# Altium schematic sheet haptic_module.SchDoc
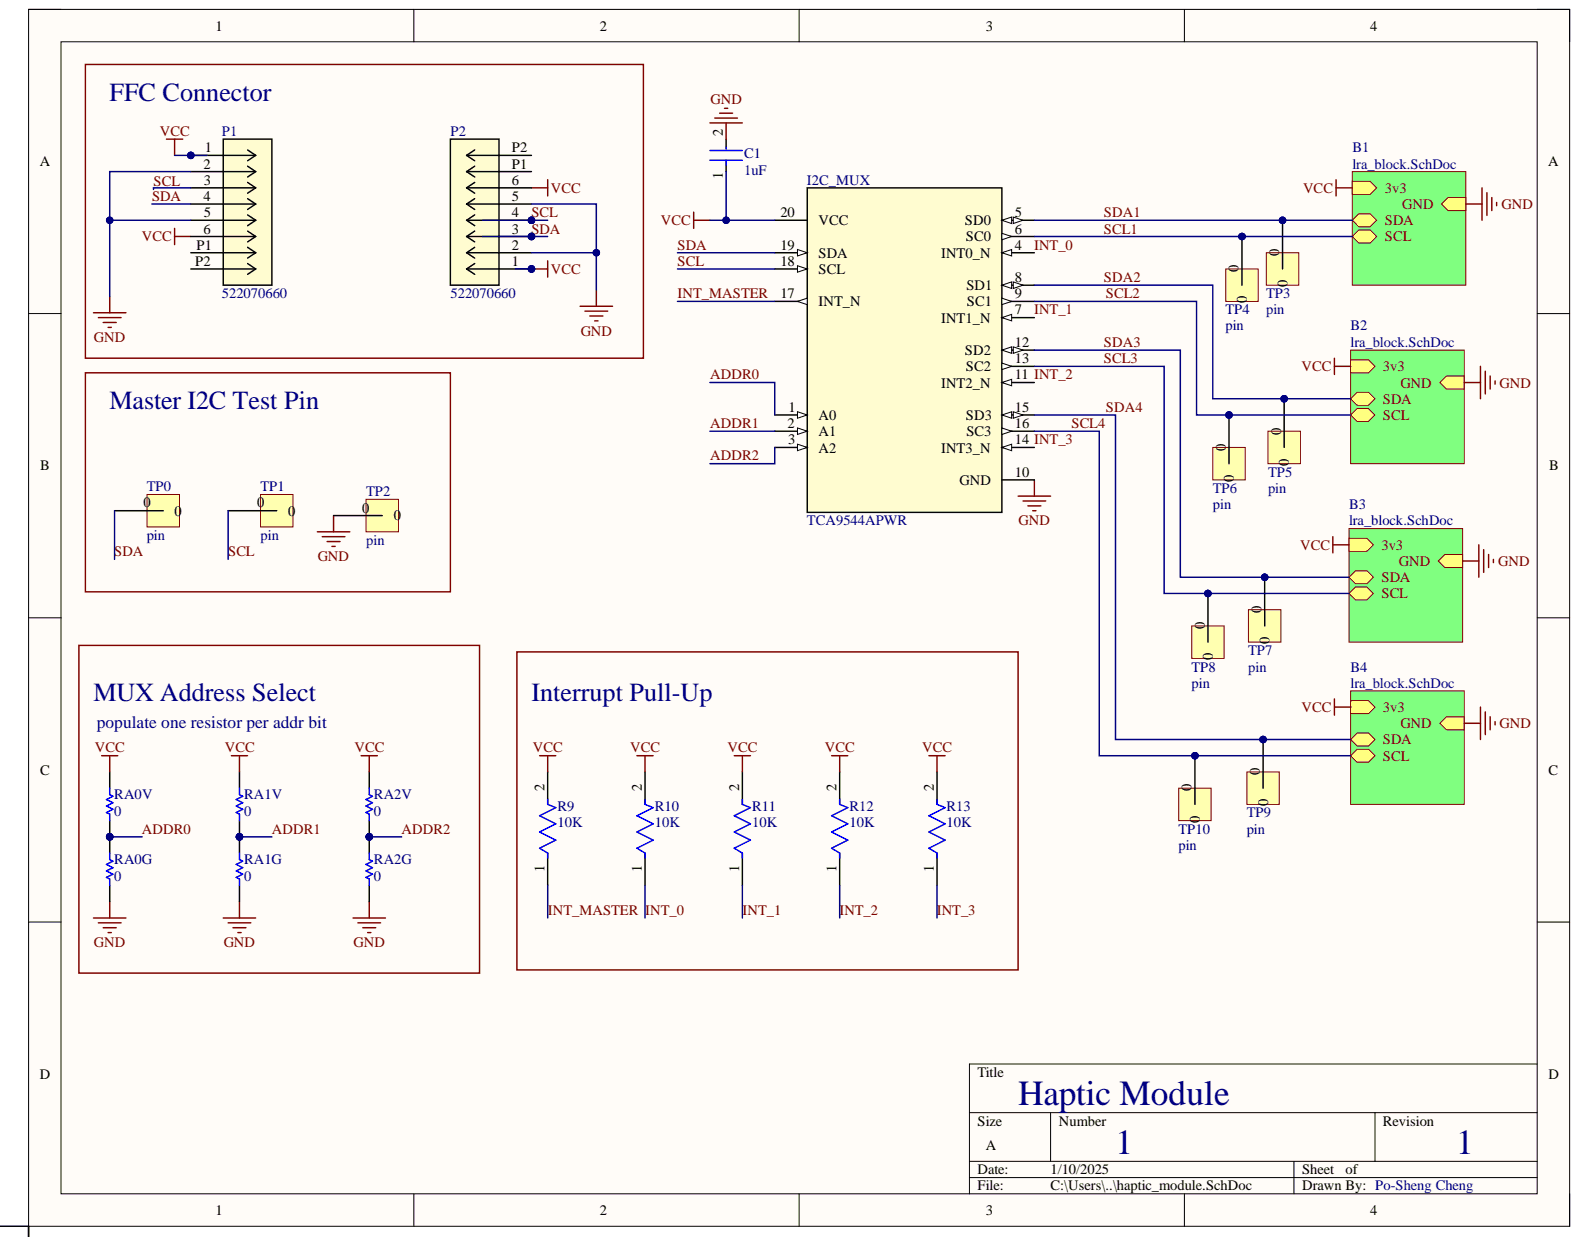
[diagram p0: haptic_module.SchDoc]

## Parts
C1  1uF  [FP-CC0805-0_85-MFG]
I2C_MUX  TCA9544APWR  [PW0020A_L]
P1  522070660  [CON_522070660_MOL]
P2  522070660  [CON_522070660_MOL]
R9  10K  [FP-RT0603-MFG]
R10  10K  [FP-RT0603-MFG]
R11  10K  [FP-RT0603-MFG]
R12  10K  [FP-RT0603-MFG]
R13  10K  [FP-RT0603-MFG]
RA0G  0  [RESC1608X55X25ML10T15]
RA0V  0  [RESC1608X55X25ML10T15]
RA1G  0  [RESC1608X55X25ML10T15]
RA1V  0  [RESC1608X55X25ML10T15]
RA2G  0  [RESC1608X55X25ML10T15]
RA2V  0  [RESC1608X55X25ML10T15]
TP0  pin  [PCBCOMPONENT_1]
TP1  pin  [PCBCOMPONENT_1]
TP2  pin  [PCBCOMPONENT_1]
TP3  pin  [PCBCOMPONENT_1]
TP4  pin  [PCBCOMPONENT_1]
TP5  pin  [PCBCOMPONENT_1]
TP6  pin  [PCBCOMPONENT_1]
TP7  pin  [PCBCOMPONENT_1]
TP8  pin  [PCBCOMPONENT_1]
TP9  pin  [PCBCOMPONENT_1]
TP10  pin  [PCBCOMPONENT_1]

## Nets
GND: C1.2 I2C_MUX.10(GND) P1.2 P1.5 P2.2 P2.5 RA0G.1 RA1G.1 RA2G.1 TP2.0
VCC: C1.1 I2C_MUX.20(VCC) P1.1 P1.6 P2.1 P2.6 R9.2 R10.2 R11.2 R12.2 R13.2 RA0V.2 RA1V.2 RA2V.2
ADDR0: I2C_MUX.1(A0) RA0G.2 RA0V.1
ADDR1: I2C_MUX.2(A1) RA1G.2 RA1V.1
ADDR2: I2C_MUX.3(A2) RA2G.2 RA2V.1
INT_0: I2C_MUX.4(INT0_N) R10.1
INT_1: I2C_MUX.7(INT1_N) R11.1
INT_2: I2C_MUX.11(INT2_N) R12.1
INT_3: I2C_MUX.14(INT3_N) R13.1
INT_MASTER: I2C_MUX.17(INT_N) R9.1
SCL: I2C_MUX.18(SCL) P1.3 P2.4 TP1.0
SCL1: I2C_MUX.6(SC0) TP4.0
SCL2: I2C_MUX.9(SC1) TP6.0
SCL3: I2C_MUX.13(SC2) TP8.0
SCL4: I2C_MUX.16(SC3) TP10.0
SDA: I2C_MUX.19(SDA) P1.4 P2.3 TP0.0
SDA1: I2C_MUX.5(SD0) TP3.0
SDA2: I2C_MUX.8(SD1) TP5.0
SDA3: I2C_MUX.12(SD2) TP7.0
SDA4: I2C_MUX.15(SD3) TP9.0
NetP1_P1: P1.P1
NetP1_P2: P1.P2
NetP2_P1: P2.P1
NetP2_P2: P2.P2

## Library data of the parts
C1 = CMP-03422-000001-1: CAP CER 1UF 16V X7R 0805; Manufacturer: Yageo; Manufacturer Part Number: CC0805KKX7R7BB105; Material: Ceramic; Tolerance: 0.1; Automotive: No; Capacitance: 1 uF; Category: Cap; Component Kind: Standard; ComponentLink2Description: Datasheet; ComponentLink2URL: http://www.yageo.com/documents/recent/UPY-GPHC_Y5V_6.3V-to-50V_8.pdf; Device Class L1: Passive Components; Device Class L2: Capacitors; Device Class L3: Ceramic Capacitors; Digikey Description: CAP CER 1UF 16V X7R 0805; Footprint Url: http://www.yageo.com/exep/pages/download/literatures/UPY-C_GEN_24.pdf; Height: 0.95mm; Ipc Land Pattern Name: CAPC200125X85; Lead Free: Yes; Library Name: Altium Content Vault; Mouser Description: Multilayer Ceramic Capacitors MLCC - SMD/SMT 1.0uF 16V X7R 10%; Octopart: https://octopart.com/cc0805kkx7r7bb105-yageo-8376555; Package: CC0805-0.85; RoHS: TRUE; Temperature: -30°C to +85°C; Temperature Characteristic: X7R; Temperature Range High: +85°C; Temperature Range Low: -30°C; Value: 1 uF; Voltage Rating: 16 V
I2C_MUX = TCA9544APWR: No Description Available; Manufacturer: Texas Instruments; CompType: IC; Component Kind: Standard; Library Name: TCA9544APWR.SchLib; Mfr_Name: Texas Instruments; MountType: SMT; PackageReference: PW0020A; PartNumber: TCA9544APWR; RefDes: RefDes; RoHS: Y; SymbolVerison: 2015-05-04; TYPE: TYPE
P1 P2 = 522070660: No Description Available; Mfr_Name: Molex Connector Corporation; Component Kind: Standard; Library Name: 522070660.SchLib; RefDes: RefDes; Type: Type
R9-R13 = CMP-2000-04517-2: RES SMD 10K OHM 0.1% 1/10W 0603; Manufacturer: Yageo; Manufacturer Part Number: RT0603BRE0710KL; Power Rating: 0.1W; Resistance: 10KΩ; Temperature Coefficient: 50 ppm/°C; Tolerance: 0.001; Value: 10KΩ; Voltage Rating: 75V; Automotive: No; Category: Res; Component Kind: Standard; ComponentLink2Description: Datasheet; ComponentLink2URL: http://www.yageo.com/documents/recent/PYu-RT_1-to-0.01_RoHS_L_9.pdf; Composition: Thin Film; Device Class L1: Passive Components; Device Class L2: Resistors; Device Class L3: Chip SMD Resistors; Digikey Description: RES SMD 10K OHM 0.1% 1/10W 0603; Footprint Url: http://www.yageo.com/exep/pages/download/literatures/PYu-R_Mount_10.pdf; Height: 0.55mm; Ipc Land Pattern Name: RESC16080X45; Lead Free: Yes; Library Name: Altium Content Vault; Mouser Description: Res Thin Film 0603 10K Ohm 0.1% 1/10W ±50ppm/°C Molded SMD SMD Paper T/R; Octopart: https://octopart.com/rt0603bre0710kl-yageo-1273959; Package: RT0603; RoHS: TRUE; Series: RT; Temperature: -55°C to +155°C; Temperature Range High: +155°C; Temperature Range Low: -55°C
RA0G RA0V RA1G RA1V RA2G RA2V = CMP-2100-03667-1: Chip Resistor, 0 Ohm, +/- 5%, 0.1 W, -55 to 155 degC, 0603 (1608 Metric), RoHS, Tape and Reel; Manufacturer: Yageo; Power Rating: 100mW; Resistance: 0mOhm; Temperature Coefficient: 200ppm/degreesC; Voltage Rating: 50V; Case Code (Imperial): 0603; Case Code (Metric): 1608; Case/Package: 0603; Component Kind: Standard; Composition: ThickFilm; Contact Plating: Tin; Datasheet URL: http://www.yageo.com/NewPortal/yageodocoutput?fileName=/pdf/R-Chip/PYu-RC_Group_51_RoHS_L_5.pdf; Datasheet Version: Rev. 5, 10/2016; Depth: 0.8mm; Height: 0.45mm; Lead Free: LeadFree; Length: 1.6mm; Library Name: Altium Content Vault; Manufacturer URL: http://www.yageo.com/; Max Operating Temperature: 155degC; Military Standard: Not; Min Operating Temperature: -55degC; Number of Pins: 2; Package Description: 2-Pin Surface Mount Device, Body 1.6 x 0.8 mm; Package Quantity: 5000; Package Reference: 0603; Packaging: TapeandReel; Radiation Hardening: No; REACH SVHC: NoSVHC; RoHSCompliant: true; Termination: SMD/SMT; Tolerance: 5%; Voltage Rating (DC): 50V; Width: 0.8mm
TP0-TP10 = pin: Component Kind: Standard; Library Name: pin.SchLib
